# Altium schematic sheet Gate_Drivers.SchDoc(Gate_Drivers)
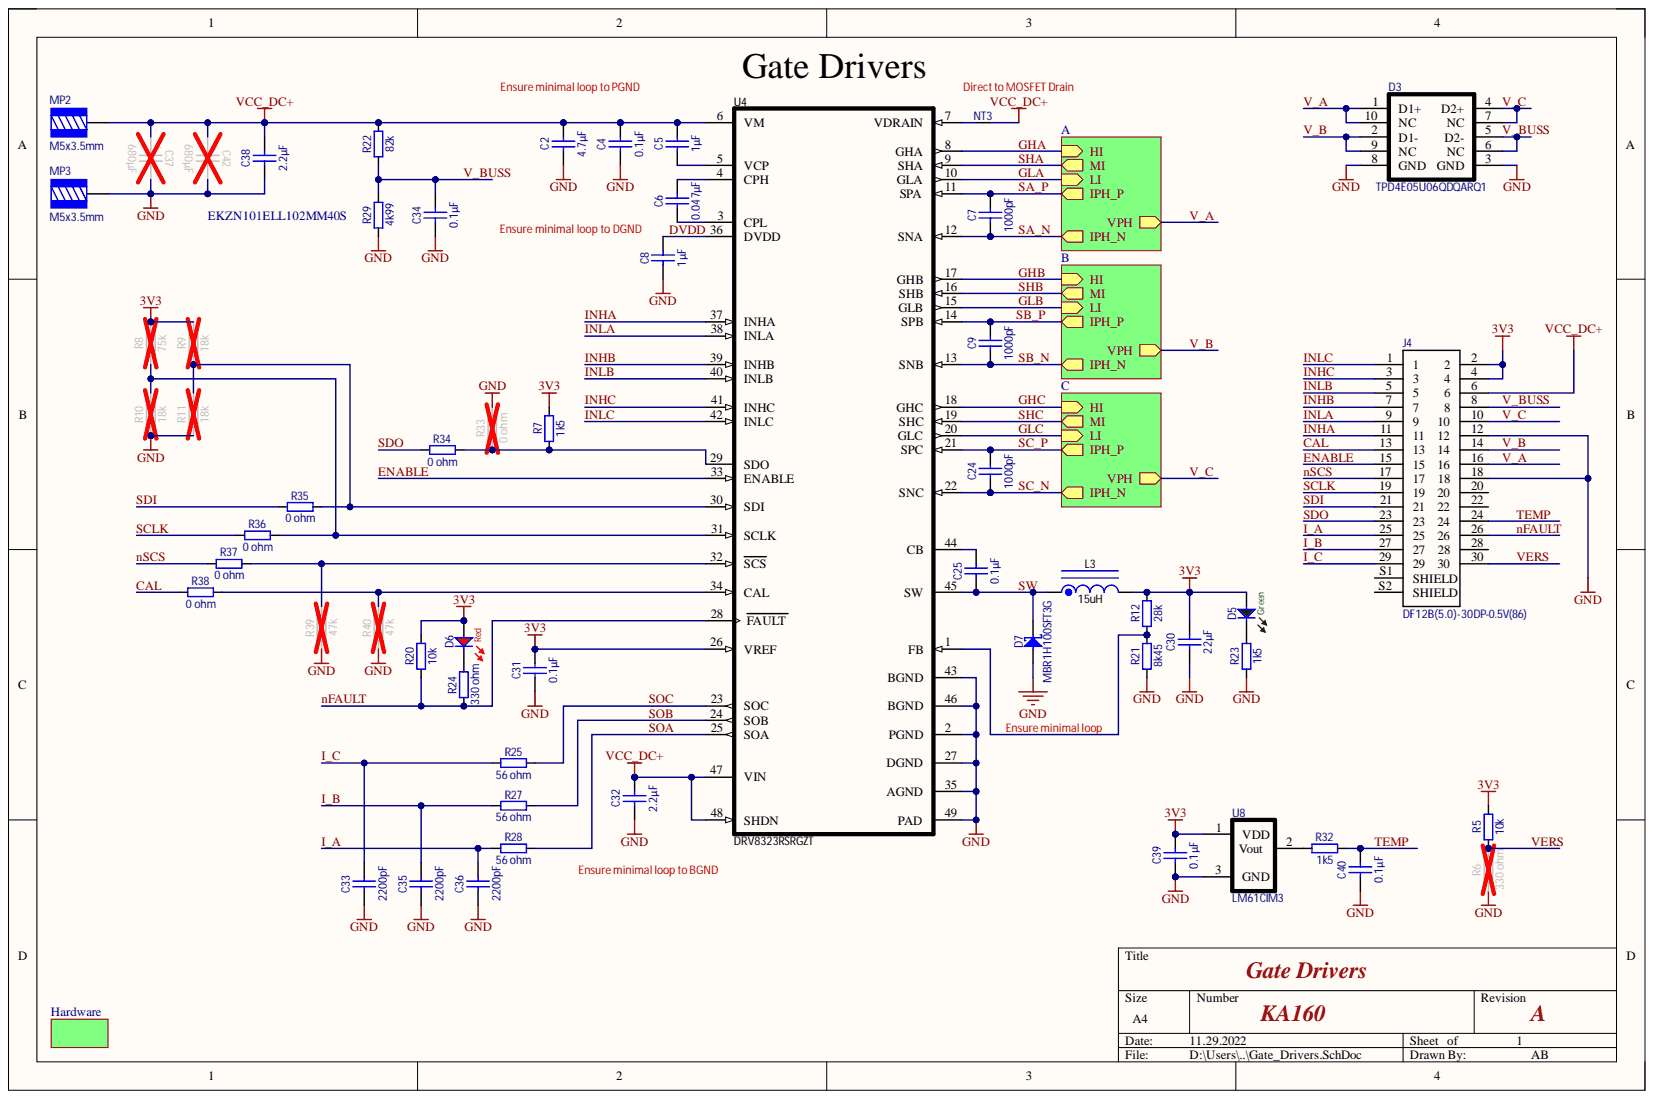
[diagram p0: Gate_Drivers.SchDoc]

## Parts
C2  4.7µF  [CAPC5750X25N]
C4  0.1µF  [CAPC1608X87N]
C5  1µF  [CAPC1608X87N]
C6  0.047µF  [CAPC1608X87N]
C7  1000pF  [CAPC1005X55N]
C8  1µF  [CAPC1608X87N]
C9  1000pF  [CAPC1005X55N]
C24  1000pF  [CAPC1005X55N]
C25  0.1µF  [CAPC1608X87N]
C30  22µF  [CAPC3216X180N]
C31  0.1µF  [CAPC1005X55N]
C32  2.2µF  [CAPC3225X140N]
C33  2200pF  [CAPC1005X55N]
C34  0.1µF  [CAPC1005X55N]
C35  2200pF  [CAPC1005X55N]
C36  2200pF  [CAPC1005X55N]
C37  680µF  [CAPPR750-1850X3750 - H]
C38  2.2µF  [CAPC3225X140N]
C39  0.1µF  [CAPC1005X55N]
C40  0.1µF  [CAPC1005X55N]
C42  680µF  [CAPPR750-1850X3750 - H]
D3  TPD4E05U06QDQARQ1  [DQA0010A]
D5  Green  [WL-SMCC_0402 G]
D6  Red  [WL-SMCC_0402 R]
D7  MBR1H100SFT3G  [SOD-123FL]
J4  DF12B(5.0)-30DP-0.5V(86)  [HRS_DF12(5.0)-30DP-0.5V(86)]
L3  value 15uH  [SD53]  (hidden comment: SD53-150-R)
MP2  M5x3.5mm  [74655095]
MP3  M5x3.5mm  [74655095]
NT3  NT  [diff_net_tie 03]
R5  10k  [RESC1005X04L]
R6  330 ohm  value 330  [RESC1005X04L]
R7  1k5  [RESC1005X04L]
R8  75k  [RESC1005X04L]
R9  18k  [RESC1005X04L]
R10  18k  [RESC1005X04L]
R11  18k  [RESC1005X04L]
R12  28k  [RESC1005X04L]
R20  10k  [RESC1005X04L]
R21  8k45  [RESC1005X04L]
R22  82k  [RESC1608X55N]
R23  1k5  [RESC1005X04L]
R24  330 ohm  value 330  [RESC1005X04L]
R25  56 ohm  value 56  [RESC1005X04L]
R27  56 ohm  value 56  [RESC1005X04L]
R28  56 ohm  value 56  [RESC1005X04L]
R29  4k99  [RESC1005X04L]
R32  1k5  [RESC1005X04L]
R33  0 ohm  value 0  [RESC1005X04L]
R34  0 ohm  value 0  [RESC1005X04L]
R35  0 ohm  value 0  [RESC1005X04L]
R36  0 ohm  value 0  [RESC1005X04L]
R37  0 ohm  value 0  [RESC1005X04L]
R38  0 ohm  value 0  [RESC1005X04L]
R39  47k  [RESC1005X04L]
R40  47k  [RESC1005X04L]
U4  DRV8323RSRGZT  [RGZ0048B]
U8  LM61CIM3  [SOT23_N]

## Nets
3V3: C30.2 C31.2 C39.2 D5.2 D6.2 J4.2 J4.4 L3.2 R5.2 R7.2 R8.2 R9.2 R12.2 R20.2 U4.26(VREF) U8.1
GND: C2.1 C4.1 C8.1 C30.1 C31.1 C32.1 C33.1 C34.1 C35.1 C36.1 C37.2 C38.1 C39.1 C40.1 C42.2 D3.3(GND) D3.8(GND) D7.2 J4.12 J4.18 MP3.1 R6.1 R10.1 R11.1 R21.1 R23.1 R29.1 R33.2 R39.1 R40.1 U4.2(PGND) U4.27(DGND) U4.35(AGND) U4.43(BGND) U4.46(BGND) U4.49(PAD) U8.3
VCC_DC+: C2.2 C4.2 C5.2 C32.2 C37.1 C38.2 C42.1 J4.6 MP2.1 NT3.2 R22.2 U4.6(VM) U4.47(VIN) U4.48(SHDN)
CAL: J4.13 R38.1
DVDD: C8.2 U4.36(DVDD)
ENABLE: J4.15 U4.33(ENABLE)
GHA: U4.8(GHA)
GHB: U4.17(GHB)
GHC: U4.18(GHC)
GLA: U4.10(GLA)
GLB: U4.15(GLB)
GLC: U4.20(GLC)
I_A: C36.2 J4.25 R28.1
I_B: C35.2 J4.27 R27.1
I_C: C33.2 J4.29 R25.1
INHA: J4.11 U4.37(INHA)
INHB: J4.7 U4.39(INHB)
INHC: J4.3 U4.41(INHC)
INLA: J4.9 U4.38(INLA)
INLB: J4.5 U4.40(INLB)
INLC: J4.1 U4.42(INLC)
nFAULT: J4.26 R20.1 R24.1 U4.28(FAULT)
nSCS: J4.17 R37.1
SA_N: C7.1 U4.12(SNA)
SA_P: C7.2 U4.11(SPA)
SB_N: C9.1 U4.13(SNB)
SB_P: C9.2 U4.14(SPB)
SC_N: C24.1 U4.22(SNC)
SC_P: C24.2 U4.21(SPC)
SCLK: J4.19 R36.1
SDI: J4.21 R35.1
SDO: J4.23 R34.1
SHA: U4.9(SHA)
SHB: U4.16(SHB)
SHC: U4.19(SHC)
SOA: R28.2 U4.25(SOA)
SOB: R27.2 U4.24(SOB)
SOC: R25.2 U4.23(SOC)
SW: C25.1 D7.1 L3.1 U4.45(SW)
TEMP: C40.2 J4.24 R32.2
V_A: D3.1(D1+) D3.10(NC) J4.16
V_B: D3.2(D1-) D3.9(NC) J4.14
V_BUSS: C34.2 D3.5(D2-) D3.6(NC) J4.8 R22.1 R29.2
V_C: D3.4(D2+) D3.7(NC) J4.10
VERS: J4.30 R5.1 R6.2
NetC5_1: C5.1 U4.5(VCP)
NetC6_1: C6.1 U4.3(CPL)
NetC6_2: C6.2 U4.4(CPH)
NetC25_2: C25.2 U4.44(CB)
NetD5_1: D5.1 R23.2
NetD6_1: D6.1 R24.2
NetJ4_20: J4.20
NetJ4_22: J4.22
NetJ4_28: J4.28
NetJ4_S1: J4.S1(SHIELD)
NetJ4_S2: J4.S2(SHIELD)
NetNT3_1: NT3.1 U4.7(VDRAIN)
NetR7_1: R7.1 R33.1 R34.2 U4.29(SDO)
NetR8_1: R8.1 R10.2 R36.2 U4.31(SCLK)
NetR9_1: R9.1 R11.2 R35.2 U4.30(SDI)
NetR12_1: R12.1 R21.2 U4.1(FB)
NetR32_1: R32.1 U8.2
NetR37_2: R37.2 R39.2 U4.32(SCS)
NetR38_2: R38.2 R40.2 U4.34(CAL)

## Library data of the parts
C2 = C2220_4u7 100V: CAP, CERM, 2220, 4.7 µF, 100 V, ± 10%, X7R; Manufacturer: TDK; Manufacturer1: AVX; Manufacturer1 Part Number: 22201C475KAT2A; Manufacturer Part Number: C5750X7R2A475K230KA; Part Description: CAP, CERM, 2220, 3.3 µF, 100 V, ± 10%, X7R; Cap_F: 0,0000047; Database Table Name: Capacitor_AB; Value: 4.7uF; Voltage: 100V; Case: 2220; Component Height: 2.3mm; Component Kind: Standard; Designator: C2220_3u3 100V; Dielectric: X7R; Library Name: Capacitor_AB.DBLib; Part Number: C2220_4u7 100V; Pin Count: 2; SMD: Yes; Supplier 1: Farnell; Supplier 2: RSComponents; Supplier 3: Digi-Key; Supplier Part Number 1: 1843192; Supplier Part Number 3: 445-7940-1-ND; Tolerance: ±10%; ValueDisplayed: 4.7µF
C4 = C0603_100nF 100V: CAP, CERM, 0603, 0.1 µF, 100 V, ± 10%, X7R; Manufacturer: MURATA; Manufacturer Part Number: GRM188R72A104KA35D; Part Description: CAP, CERM, 0603, 0.1 µF, 50 V, ± 10%, X7R; Cap_F: 0,0000001; Database Table Name: Capacitor_AB; Value: 0.1uF; Voltage: 100V; Case: 0603; Component Height: 0.9mm; Component Kind: Standard; Designator: C0603_100nF 100V; Dielectric: X7R; Library Name: Capacitor_AB.DBLib; Part Number: C0603_100nF 100V; Pin Count: 2; SMD: Yes; Supplier 1: Farnell; Supplier 2: RSComponents; Supplier 3: Digi-Key; Supplier Part Number 3: 490-3285-1-ND; Tolerance: 10%; ValueDisplayed: 0.1µF
C5 C8 = C0603_1uF 35V: CAP, CERM, 0603, 1 µF, 35 V, ± 10%, X7R; Manufacturer: TDK; Manufacturer Part Number: C1608X7R1V105K080AC; Database Table Name: Capacitor_AB; Value: 1uF; Voltage: 35V; Case: 0603; Component Height: 0.95mm; Component Kind: Standard; Designator: C0603_1uF 35V; Dielectric: X7R; Library Name: Capacitor_AB.DBLib; Part Description: CAP, CERM, 0603, 1 µF, 35 V, ± 10%, X7R; Part Number: C0603_1uF 35V; Pin Count: 2; SMD: Yes; Supplier 1: Farnell; Supplier 2: RSComponents; Supplier 3: Digi-Key; Supplier Part Number 1: 2346909; Tolerance: ±10%; ValueDisplayed: 1µF
C6 = C0603_47nF 100V: CAP, CERM, 0603, 0.047 µF, 100 V, ± 10%, X7S; Manufacturer: TDK; Manufacturer1 Part Number: C1608X7S2A473K080AB; Manufacturer Part Number: CGA3E3X7S2A473K080AB; Part Description: CAP, CERM, 0603, 0.047 µF, 50 V, ± 5%, X7S; Cap_F: 0,000000047; Database Table Name: Capacitor_AB; Value: 0.047uF; Voltage: 50V; Case: 0603; Component Height: 0.87mm; Component Kind: Standard; Designator: C0603_47nF 100V; Dielectric: X7S; Library Name: Capacitor_AB.DBLib; Manufacturer1: TDK; Part Number: C0603_47nF 100V; Pin Count: 2; SMD: Yes; Supplier 1: Farnell; Supplier 2: RSComponents; Supplier 3: Digi-Key; Supplier Part Number 1: 2906791; Supplier Part Number 3: 445-6936-1-ND; Tolerance: ±10%; ValueDisplayed: 0.047µF
C7 C9 C24 = C0402_1n 50V: CAP, CERM, 0402, 1000 pF, 50 V, ± 10%, X7R; Manufacturer: MURATA; Manufacturer Part Number: GCM155R71H102KA37D; Cap_F: 0,000000001; Database Table Name: Capacitor_AB; Voltage: 50V; Case: 0402; Component Height: 0.55mm; Component Kind: Standard; Designator: C0402_1n 50V; Dielectric: X7R; Library Name: Capacitor_AB.DBLib; Part Description: CAP, CERM, 0402, 1000 pF, 50 V, ± 10%, X7R; Part Number: C0402_1n 50V; Pin Count: 2; SMD: Yes; Supplier 1: Farnell; Supplier 2: RSComponents; Supplier 3: Digi-Key; Supplier Part Number 1: 2470415; Supplier Part Number 3: 490-4761-6-ND; Tolerance: ±10%; Value: 1000pF; ValueDisplayed: 1000pF
C25 = C0603_100nF 50V: CAP, CERM, 0603, 0.1 µF, 50 V, ± 10%, X7R; Manufacturer: KEMET; Manufacturer Part Number: C0603X104K5RACTU; Database Table Name: Capacitor_AB; Value: 0.1uF; Voltage: 50V; Case: 0603; Component Height: 0.87mm; Component Kind: Standard; Designator: C0603_100nF 50V; Dielectric: X7R; Library Name: Capacitor_AB.DBLib; Part Description: CAP, CERM, 0603, 0.1 µF, 50 V, ± 10%, X7R; Part Number: C0603_100nF 50V; Pin Count: 2; SMD: Yes; Supplier 1: Farnell; Supplier 2: RSComponents; Supplier 3: Digi-Key; Supplier Part Number 1: 2629474; Tolerance: 10%; ValueDisplayed: 0.1µF
C30 = C1206_22u 6.3V: CAP, CERM, 1206, 22 µF, 6.3 V, ± 10%, X7R; Manufacturer: MURATA; Manufacturer1: AVX; Manufacturer1 Part Number: 12066C226KAT2A; Manufacturer Part Number: GCM31CR70J226ME23L; Cap_F: 0,000022; Database Table Name: Capacitor_AB; Value: 22uF; Voltage: 6.3V; Case: 1206; Component Height: 1.6mm; Component Kind: Standard; Designator: C1206_22u 6.3V; Dielectric: X7R; Library Name: Capacitor_AB.DBLib; Part Description: CAP, CERM, 1206, 22 µF, 6.3 V, ± 10%, X7R; Part Number: C1206_22u 6.3V; Pin Count: 2; SMD: Yes; Supplier 1: Farnell; Supplier 2: RSComponents; Supplier 3: Digi-Key; Supplier Part Number 1: 1845771; Supplier Part Number 3: 490-4843-6-ND; Tolerance: ±10%; ValueDisplayed: 22µF
C31 C34 C39 C40 = C0402_100nF 16V: CAP, CERM, 0402, 0.1 µF, 16 V, ± 10%, X7R; Manufacturer: WALSIN; Manufacturer1: Samsung; Manufacturer1 Part Number: CL05B104KO5NNNC; Manufacturer Part Number: 0402B104K160CT; Cap_F: 0,0000001; Database Table Name: Capacitor_AB; Value: 0.1uF; Voltage: 16V; Case: 0402; Component Height: 0.55mm; Component Kind: Standard; Designator: C0402_100nF 16V; Dielectric: X7R; Library Name: Capacitor_AB.DBLib; Part Description: CAP, CERM, 0402, 0.1 µF, 16 V, ± 10%, X7R; Part Number: C0402_100nF 16V; Pin Count: 2; SMD: Yes; Supplier 1: Farnell; Supplier 2: RSComponents; Supplier 3: Digi-Key; Supplier Part Number 1: 2496771; Supplier Part Number 3: 1276-1001-1-ND; Tolerance: ±10%; ValueDisplayed: 0.1µF
C32 = C1210_2u2 100V: CAP, CERM, 1210, 2.2 µF, 100 V, ± 10%, X7R; Manufacturer: MURATA; Manufacturer Part Number: GCJ32DR72A225KA01L; Part Description: CAP, CERM, 1210, 2.2 µF, 50 V, ± 10%, X7R; Database Table Name: Capacitor_AB; Value: 2.2uF; Voltage: 100V; Case: 1210; Component Height: 2mm; Component Kind: Standard; Designator: C1210_2u2 100V; Dielectric: X7R; Library Name: Capacitor_AB.DBLib; Part Number: C1210_2u2 100V; Pin Count: 2; SMD: Yes; Supplier 1: Farnell; Supplier 2: RSComponents; Supplier 3: Digi-Key; Supplier Part Number 1: 2781417; Supplier Part Number 3: 490-5840-1-ND; Tolerance: ±10%; ValueDisplayed: 2.2µF
C33 C35 C36 = C0402_2n2 50V: CAP, CERM, 0402, 2200 pF, 50 V, ± 10%, X7R; Manufacturer: MURATA; Manufacturer Part Number: GCM155R71H222KA37D; Cap_F: 0,0000000022; Database Table Name: Capacitor_AB; Voltage: 50V; Case: 0402; Component Height: 0.55mm; Component Kind: Standard; Designator: C0402_2n2 50V; Dielectric: X7R; Library Name: Capacitor_AB.DBLib; Part Description: CAP, CERM, 0402, 2200 pF, 50 V, ± 10%, X7R; Part Number: C0402_2n2 50V; Pin Count: 2; SMD: Yes; Supplier 1: Farnell; Supplier 2: RSComponents; Supplier 3: Digi-Key; Supplier Part Number 1: 2470415; Supplier Part Number 3: 490-4914-1-ND; Tolerance: ±10%; Value: 2200pF; ValueDisplayed: 2200pF
C37 C42 = Cap - pol 680uF 100V 18x37: Electrolytic Capacitor, 680 µF, 100 V; Manufacturer: United Chemi-Con; Manufacturer Part Number: EGVD101ELL681MMP1H; Cap_F: 0,00068; Database Table Name: Capacitor_AB; Tolerance: ±20%; Value: 680uF; Voltage: 100V; Case: 18x37; Component Height: 37.5mm; Component Kind: Standard; Designator: Cap - polarized 680uF 100V 18x37; Library Name: Capacitor_AB.DBLib; Part Description: Electrolytic Capacitor, 680 µF, 100 V; Part Number: Cap - pol 680uF 100V 18x37; Pin Count: 2; SMD: No; Supplier 1: Farnell; Supplier 2: RSComponents; Supplier 3: Digi-Key; Supplier Part Number 3: 565-4435-ND; ValueDisplayed: 680µF
C38 = C1210_2u2 100V: CAP, CERM, 1210, 2.2 µF, 100 V, ± 10%, X7R; Manufacturer: MURATA; Manufacturer1 Part Number: GRM32ER72A225KA35L; Manufacturer Part Number: GCJ32DR72A225KA01L; Cap_F: 0,0000022; Database Table Name: Capacitor_AB; Value: 2.2uF; Voltage: 100V; Case: 1210; Component Height: 2mm; Component Kind: Standard; Designator: C1210_2u2 100V; Dielectric: X7R; Library Name: Capacitor_AB.DBLib; Manufacturer1: MURATA; Part Description: CAP, CERM, 1210, 2.2 µF, 100 V, ± 10%, X7R; Part Number: C1210_2u2 100V; Pin Count: 2; SMD: Yes; Supplier 1: Farnell; Supplier 2: RSComponents; Supplier 3: Digi-Key; Supplier Part Number 1: 1828843; Supplier Part Number 3: 490-5840-1-ND; Tolerance: ±10%; ValueDisplayed: 2.2µF
D3 = ESD TPD4E05U06QDQARQ1: TVS DIODE 5.5V 14V 10USON; Manufacturer: Texas Instruments; Voltage: 5V; Case: USON; Component Kind: Standard; Library Name: My_PCB.SchLib; Manufacturer1 ComponentHeight: 0.6mm; Manufacturer Part Number: TPD4E05U06QDQARQ1; Mounted: Yes; PackageDescription: 10-USON (2,5x1); Part Description: TVS DIODE 5.5V 14V 10USON; Part Number: TPD4E05U06QDQARQ1; Pin Count: 10; SMD: Yes; Supplier 1: Farnell; Supplier 2: RSComponents; Supplier 3: Digi-Key; Supplier Part Number 3: 296-40696-1-ND; Author: AB
D5 = LED Green 0402: LED Green 0402 WL-SMCC; Manufacturer: Wurth Elektronik; Manufacturer Part Number: 150040VS73240; Case: 0402; Color: Green; Component Kind: Standard; Library Name: My_PCB.SchLib; Manufacturer1 ComponentHeight: 0.5mm; Mounted: Yes; PackageDescription: 0402; Part Description: LED Green 0402 WL-SMCC; Part Number: 150040VS73240; Pin Count: 2; SMD: Yes; Supplier 1: Farnell; Supplier 2: RSComponents; Supplier 3: Digi-Key; Supplier Part Number 1: 2900755; Supplier Part Number 3: 732-11992-1-ND; Author: AB
D6 = 150040RS73240: LED Red 0402 WL-SMCC; Manufacturer: WURTH; Case: 0402; Color: Red; Component Kind: Standard; Library Name: My_PCB.SchLib; Manufacturer1 ComponentHeight: 0.5mm; Manufacturer Part Number: 150040RS73240; Mounted: Yes; PackageDescription: 0402; Part Description: LED Red 0402 WL-SMCC; Part Number: 150040RS73240; Pin Count: 2; SMD: Yes; Supplier 1: Farnell; Supplier 2: RSComponents; Supplier 3: Digi-Key; Supplier Part Number 1: 2900754; Supplier Part Number 3: 732-11991-1-ND; Author: CERN DEM JLC
D7 = MBR1H100SFT3G: Schottky Diode 1A 100V; Manufacturer: ON SEMICONDUCTOR; Part Description: Power Schottky rectifier 1A 100V; Case: SOD-123FL; Component Kind: Standard; Library Name: My_PCB.SchLib; Manufacturer1 ComponentHeight: 2.65mm; Manufacturer Part Number: MBR1H100SFT3G; Mounted: Yes; PackageDescription: SOD-123FL Molded Diode, Body 3.5x1.6mm, IPC Medium Density; Pin Count: 2; Power: 1A; SMD: Yes; Supplier 1: Farnell; Supplier 2: RSComponents; Supplier 3: Digi-Key; Supplier Part Number 1: 2533229; Supplier Part Number 3: MBR1H100SFT3GOSCT-ND; Voltage: 100V; Author: CERN DEM JLC
J4 = Connector 30 DF12(5.0)-30DP-0.5V(86): 30 Position Connector Header, PCB to PCB, 5mm; Manufacturer: Hirose Electric; Component Kind: Standard; Library Name: My_PCB.SchLib; Manufacturer Part Number: DF12B(5.0)-30DP-0.5V(86); Mounted: YES; Part Description: 30 Position Connector Header, PCB to PCB, 5mm; Part Number: DF12B(5.0)-30DP-0.5V(86); Pin Count: 20; Price: None; SMD: YES; Supplier 1: Farnell; Supplier 2: RSComponents; Supplier 3: Digi-Key; Supplier Part Number 3: H11731CT-ND; System: Connector
L3 = L SD53-150-R: Inductor, Shielded Drum Core, 15 µH, 1.1 A, 0.122 ohm, SMD; Manufacturer: Eaton; Part Description: FIXED IND 15UH 1.1A 142 MOHM SMD; ValueDisplayed: 15µH; Component Kind: Standard; Library Name: My_PCB.SchLib; Manufacturer1 ComponentHeight: 3mm; Manufacturer Part Number: SD53-150-R; Mounted: Yes; PackageDescription: 5.72x3x5.2mm; Part Number: SD53-150-R; Pin Count: 2; Price: 1,89 €; Signal Integrity: Inductor; Simulation: Inductor; SMD: Yes; Supplier 1: Digi-Key; Supplier 2: Mouser; Supplier 3: Newark; Supplier Part Number 1: 513-1458-1-ND; Supplier Part Number 2: 704-SD53-150-R; Supplier Part Number 3: 99M5528
MP2 MP3 = 74655095R: WP-THRBU REDCUBE THR internal through-hole thread, 10x10mm, M5x3.5mm, 8 pins, 85A; Manufacturer: Wurth Elektronik; Pin Number: 8; Surface: Tin; Thread: M5; Thread Length: 3.5mm; Component Kind: Standard; ComponentLink1Description: Manufacturer; ComponentLink1URL: http://www.we-online.de; ComponentLink2Description: Datasheet; ComponentLink2URL: https://katalog.we-online.com/em/datasheet/74655095R.pdf; Height: 4mm; Library Name: 74655095R_Altium_WP-THRBU_rev19a.IntLib; Manufacturer Part Number: 74655095R; Material: Brass; Mounting Technology: SMT; Operating Temperature: -55 to +150degC; Packaging: Tape and Reel; Part Number: 74655095R; Published Date: September 2019; Rated Current: 85A; Size: 10x10mm; Supplier 1: Farnell; Supplier 2: RSComponents; Supplier 3: Digi-Key; Supplier Part Number 1: 2748947; Supplier Part Number 3: 732-10903-1-ND; Publisher: Wurth Elektronik
NT3 = diffpair_net_tie: Component Kind: Net Tie; Library Name: My_PCB.SchLib
R5 R20 = R0402_10k: RES, 10 kohm, 50 V, 0402, 63 mW; Manufacturer: MULTICOMP; Manufacturer1: TE Connectivity; Manufacturer1 Part Number: CRG0402F10K; Manufacturer Part Number: MCMR04X1002FTL; Database Table Name: Resistor_AB; Power: 0.0625W; TC: ±100ppm/°C; Tolerance: ±1%; Value: 10000; Case: 0402; Component Height: 0.4mm; Component Kind: Standard; Designator: R0402_10K; Library Name: Resistor_AB.DbLib; Part Description: RES, 10 kohm, 50 V, 0402, 63 mW; Part Number: R0402_10k; Pin Count: 2; SMD: Yes; Supplier 1: Farnell; Supplier 2: RSComponents; Supplier 3: Digi-Key; Supplier Part Number 1: 2072517; Supplier Part Number 3: 541-3959-1-ND; ValueDisplayed: 10k
R6 R24 = R0402_330R: RES, 330 ohm, 50 V, 0402, 100 mW; Manufacturer: PANASONIC; Manufacturer1: KOA; Manufacturer1 Part Number: RK73H1ETTP3300F; Manufacturer Part Number: ERJ2RKF3300X; Database Table Name: Resistor_AB; Power: 0.1W; TC: ±100ppm/°C; Tolerance: ±1%; Case: 0402; Component Height: 0.4mm; Component Kind: Standard; Designator: R0402_330R; Library Name: Resistor_AB.DbLib; Part Description: RES, 330 ohm, 50 V, 0402, 100 mW; Part Number: R0402_330R; Pin Count: 2; SMD: Yes; Supplier 1: Farnell; Supplier 2: RSComponents; Supplier 3: Digi-Key; Supplier Part Number 1: 2302581; Supplier Part Number 3: P330LCT-ND; ValueDisplayed: 330 ohm
R7 R23 R32 = R0402_1k5: RES, 1.5 kohm, 50 V, 0402, 100 mW; Manufacturer: PANASONIC; Manufacturer Part Number: ERJ-2RKF1501X; Database Table Name: Resistor_AB; Power: 0.1W; TC: ±100ppm/°C; Tolerance: ±1%; Value: 1500; Case: 0402; Component Height: 0.4mm; Component Kind: Standard; Designator: R0402_1k5; Library Name: Resistor_AB.DbLib; Part Description: RES, 1.5 kohm, 50 V, 0402, 100 mW; Part Number: R0402_1k5; Pin Count: 2; SMD: Yes; Supplier 1: Farnell; Supplier 2: RSComponents; Supplier 3: Digi-Key; Supplier Part Number 3: P1.50KLCT-ND; ValueDisplayed: 1k5
R8 = R0402_75k: RES, 75 kohm, 50 V, 0402, 100 mW; Manufacturer: PANASONIC; Manufacturer Part Number: ERJ-2RKF7502X; Database Table Name: Resistor_AB; Power: 0.1W; TC: ±100ppm/°C; Tolerance: ±1%; Value: 75000; Case: 0402; Component Height: 0.4mm; Component Kind: Standard; Designator: R0402_75K; Library Name: Resistor_AB.DbLib; Part Description: RES, 75 kohm, 50 V, 0402, 100 mW; Part Number: R0402_75k; Pin Count: 2; SMD: Yes; Supplier 1: Farnell; Supplier 2: RSComponents; Supplier 3: Digi-Key; Supplier Part Number 3: P75.0KLCT-ND; ValueDisplayed: 75k
R9-R11 = R0402_18k: RES, 18 kohm, 50 V, 0402, 100 mW; Manufacturer: PANASONIC; Manufacturer Part Number: ERJ-2RKF1802X; Database Table Name: Resistor_AB; Power: 0.1W; TC: ±100ppm/°C; Tolerance: ±1%; Value: 18000; Case: 0402; Component Height: 0.4mm; Component Kind: Standard; Designator: R0402_18K; Library Name: Resistor_AB.DbLib; Part Description: RES, 18 kohm, 50 V, 0402, 100 mW; Part Number: R0402_18k; Pin Count: 2; SMD: Yes; Supplier 1: Farnell; Supplier 2: RSComponents; Supplier 3: Digi-Key; Supplier Part Number 3: P18.0KLCT-ND; ValueDisplayed: 18k
R12 = R0402_28k: RES, 28 kohm, 50 V, 0402, 100 mW; Manufacturer: PANASONIC; Manufacturer Part Number: ERJ-2RKF2802X; Database Table Name: Resistor_AB; Power: 0.1W; TC: ±100ppm/°C; Tolerance: ±1%; Value: 28000; Case: 0402; Component Height: 0.4mm; Component Kind: Standard; Designator: R0402_28K; Library Name: Resistor_AB.DbLib; Part Description: RES, 28 kohm, 50 V, 0402, 100 mW; Part Number: R0402_28k; Pin Count: 2; SMD: Yes; Supplier 1: Farnell; Supplier 2: RSComponents; Supplier 3: Digi-Key; Supplier Part Number 3: P28.0KLCT-ND; ValueDisplayed: 28k
R21 = R0402_8k45: RES, 8.45 kohm, 50 V, 0402, 100 mW; Manufacturer: PANASONIC; Manufacturer Part Number: ERJ-2RKF8451; Database Table Name: Resistor_AB; Power: 0.1W; TC: ±100ppm/°C; Tolerance: ±1%; Value: 8450; Case: 0402; Component Height: 0.4mm; Component Kind: Standard; Designator: R0402_8k45; Library Name: Resistor_AB.DbLib; Part Description: RES, 8.45 kohm, 50 V, 0402, 100 mW; Part Number: R0402_8k45; Pin Count: 2; SMD: Yes; Supplier 1: Farnell; Supplier 2: RSComponents; Supplier 3: Digi-Key; Supplier Part Number 3: P8.45KLCT-ND; ValueDisplayed: 8k45
R22 = R0603_82k: RES, 82 kohm, 75 V, 0603, 100 mW; Manufacturer: VISHAY; Manufacturer1: YAGEO; Manufacturer1 Part Number: RC0603FR-0782KL; Manufacturer Part Number: CRCW060382K0FKEA; Database Table Name: Resistor_AB; Power: 0.063W; TC: ±100ppm/°C; Tolerance: ±1%; Value: 82000; Case: 0603; Component Height: 0.5mm; Component Kind: Standard; Designator: R0603_82k; Library Name: Resistor_AB.DbLib; Part Description: RES, 82 kohm, 75 V, 0603, 100 mW; Part Number: R0603_82k; Pin Count: 2; SMD: Yes; Supplier 1: Farnell; Supplier 2: RSComponents; Supplier 3: Digi-Key; Supplier Part Number 1: 2138490; Supplier Part Number 3: 311-82.0KHRCT-ND; ValueDisplayed: 82k
R25 R27 R28 = R0402_56R: RES, 56 ohm, 50 V, 0402, 63 mW; Manufacturer: PANASONIC; Manufacturer Part Number: ERJ-2RKF56R0X; Database Table Name: Resistor_AB; Power: 0.1W; TC: ±100ppm/°C; Tolerance: ±1%; Case: 0402; Component Height: 0.4mm; Component Kind: Standard; Designator: R0402_56R; Library Name: Resistor_AB.DbLib; Part Description: RES, 56 ohm, 50 V, 0402, 63 mW; Part Number: R0402_56R; Pin Count: 2; SMD: Yes; Supplier 1: Farnell; Supplier 2: RSComponents; Supplier 3: Digi-Key; Supplier Part Number 3: P56.0LCT-ND; ValueDisplayed: 56 ohm
R29 = R0402_4k99: RES, 4.99 kohm, 50 V, 0402, 100 mW; Manufacturer: PANASONIC; Manufacturer1: KOA; Manufacturer1 Part Number: RK73H1ETTP4991F; Manufacturer Part Number: ERJ2RKF4991X; Database Table Name: Resistor_AB; Power: 0.1W; TC: ±100ppm/°C; Tolerance: ±1%; Value: 4990; Case: 0402; Component Height: 0.4mm; Component Kind: Standard; Designator: R0402_4k99; Library Name: Resistor_AB.DbLib; Part Description: RES, 4.99 kohm, 50 V, 0402, 100 mW; Part Number: R0402_4k99; Pin Count: 2; SMD: Yes; Supplier 1: Farnell; Supplier 2: RSComponents; Supplier 3: Digi-Key; Supplier Part Number 1: 2059145; Supplier Part Number 3: P4.99KLCT-ND; ValueDisplayed: 4k99
R33-R38 = R0402_0R: RES, 0 ohm, 50 V, 0402, 63 mW; Manufacturer: VISHAY; Manufacturer Part Number: CRCW04020000Z0ED; Database Table Name: Resistor_AB; Power: 0.0625W; TC: ±100ppm/°C; Tolerance: ±1%; Case: 0402; Component Height: 0.4mm; Component Kind: Standard; Designator: R0402_0R; Library Name: Resistor_AB.DbLib; Part Description: RES, 0 ohm, 50 V, 0402, 63 mW; Part Number: R0402_0R; Pin Count: 2; SMD: Yes; Supplier 1: Farnell; Supplier 2: RSComponents; Supplier 3: Digi-Key; Supplier Part Number 1: 1469661; Supplier Part Number 3: 541-0.0YBCT-ND; ValueDisplayed: 0 ohm
R39 R40 = R0402_47k: RES, 47 kohm, 50 V, 0402, 100 mW; Manufacturer: PANASONIC; Manufacturer1: VISHAY; Manufacturer1 Part Number: CRCW040247K0FKED; Manufacturer Part Number: ERJ2RKF4702X; Database Table Name: Resistor_AB; Power: 0.1W; TC: ±100ppm/°C; Tolerance: ±1%; Value: 47000; Case: 0402; Component Height: 0.4mm; Component Kind: Standard; Designator: R0402_47K; Library Name: Resistor_AB.DbLib; Part Description: RES, 47 kohm, 50 V, 0402, 100 mW; Part Number: R0402_47k; Pin Count: 2; SMD: Yes; Supplier 1: Farnell; Supplier 2: RSComponents; Supplier 3: Digi-Key; Supplier Part Number 1: 2302806; Supplier Part Number 3: P47.0KLCT-ND; ValueDisplayed: 47k
U4 = DRV8323RSRGZT: IC 3PHASE GATE DRIVER 48VQFN; Manufacturer: Texas Instruments; Pitch: 1.0mm; Case: VQFN-48; Component Kind: Standard; Library Name: My_PCB.SchLib; Manufacturer1 ComponentHeight: 1.0mm; Manufacturer Part Number: DRV8323RSRGZT; PackageDescription: VQFN-48; Part Description: IC 3PHASE GATE DRIVER 48VQFN; Part Number: DRV8323RSRGZT; Pin Count: 48; Price: 5,89 €; SMD: Yes; Supplier 1: Farnell; Supplier 2: RSComponents; Supplier 3: Digi-Key; Supplier Part Number 1: 2769494; Supplier Part Number 3: 296-46943-1-ND
U8 = LM61CIM3: Temperature Sensor IC, Voltage, ± 3°C, -30 °C, +100 °C, SOT-23, 3 Pins; Manufacturer: Texas Instruments; Case: SOT-23; Component Kind: Standard; Library Name: My_PCB.SchLib; Manufacturer Part Number: LM61CIM3; Mounted: Yes; PackageDescription: SOT-23; Part Description: Temperature Sensor IC, Voltage, ± 3°C, -30 °C, +100 °C, SOT-23, 3 Pins; Part Number: LM61CIM3; Pin Count: 3; SMD: Yes; Supplier 1: Farnell; Supplier 2: RSComponents; Supplier 3: Digi-Key; Supplier Part Number 1: 1197388; Supplier Part Number 3: LM61CIM3X/NOPBCT-ND
